# Altium schematic sheet Principal.V01.R00.SchDoc(Principal.V01.R00)
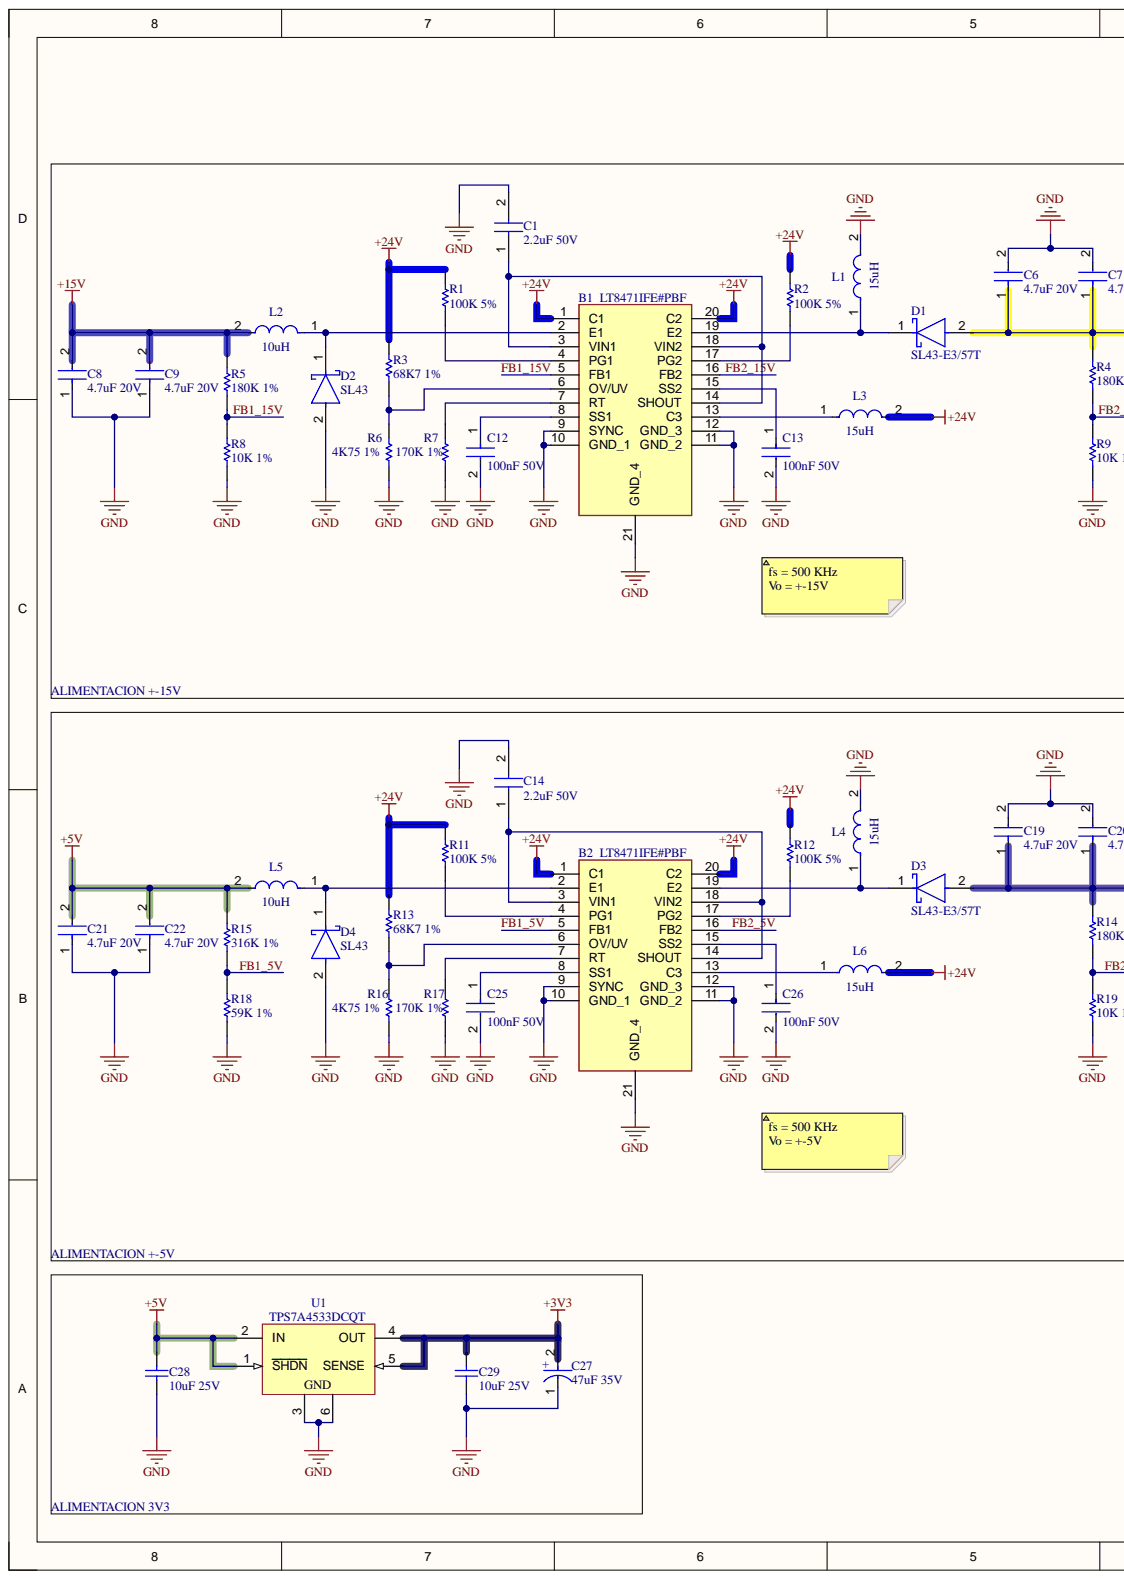
[diagram p0: Principal.V01.R00.SchDoc, left]
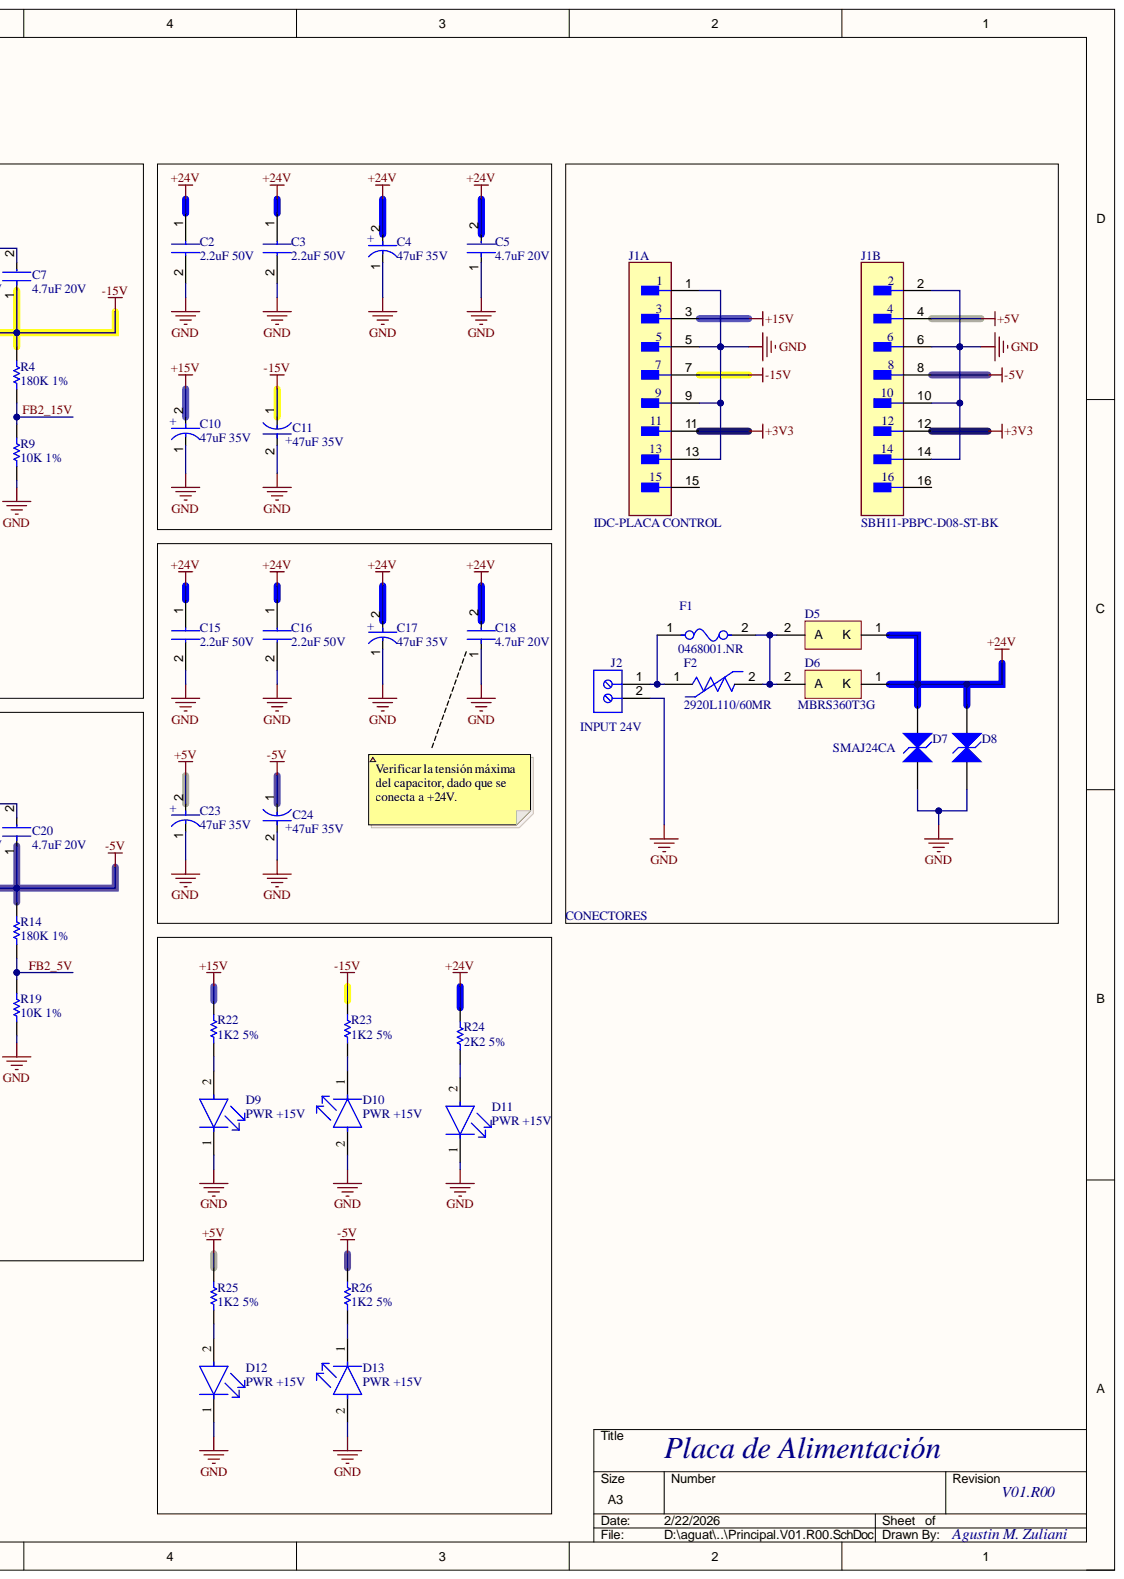
[diagram p1: Principal.V01.R00.SchDoc, right]

## Parts
B1  LT8471IFE#PBF  [SOP65P640X120-21N]
B2  LT8471IFE#PBF  [SOP65P640X120-21N]
C1  2.2uF 50V  [MBASx31x]
C2  2.2uF 50V  [MBASx31x]
C3  2.2uF 50V  [MBASx31x]
C4  47uF 35V  value 47uF  Capacitance: 47uF  [FP-FK-D-MFG]
C5  4.7uF 20V  [FP-CC1206-1_25-MFG]
C6  4.7uF 20V  [FP-CC1206-1_25-MFG]
C7  4.7uF 20V  [FP-CC1206-1_25-MFG]
C8  4.7uF 20V  [FP-CC1206-1_25-MFG]
C9  4.7uF 20V  [FP-CC1206-1_25-MFG]
C10  47uF 35V  value 47uF  Capacitance: 47uF  Voltage Rating: 35V  [FP-FK-D-MFG]
C11  47uF 35V  value 47uF  Capacitance: 47uF  Voltage Rating: 35V  [FP-FK-D-MFG]
C12  100nF 50V  [FP-C1206C-EB-MFG]
C13  100nF 50V  [FP-C1206C-EB-MFG]
C14  2.2uF 50V  [MBASx31x]
C15  2.2uF 50V  [MBASx31x]
C16  2.2uF 50V  [MBASx31x]
C17  47uF 35V  value 47uF  Capacitance: 47uF  Voltage Rating: 35V  [FP-FK-D-MFG]
C18  4.7uF 20V  [FP-CC1206-1_25-MFG]
C19  4.7uF 20V  [FP-CC1206-1_25-MFG]
C20  4.7uF 20V  [FP-CC1206-1_25-MFG]
C21  4.7uF 20V  [FP-CC1206-1_25-MFG]
C22  4.7uF 20V  [FP-CC1206-1_25-MFG]
C23  47uF 35V  value 47uF  Capacitance: 47uF  Voltage Rating: 35V  [FP-FK-D-MFG]
C24  47uF 35V  value 47uF  Capacitance: 47uF  Voltage Rating: 35V  [FP-FK-D-MFG]
C25  100nF 50V  [FP-C1206C-EB-MFG]
C26  100nF 50V  [FP-C1206C-EB-MFG]
C27  47uF 35V  value 47uF  Capacitance: 47uF  Voltage Rating: 35V  [FP-FK-D-MFG]
C28  10uF 25V  value 10uF  [CAPC1812(4532)280_L]
C29  10uF 25V  value 10uF  [CAPC1812(4532)280_L]
D1  SL43-E3/57T  [FP-95402-MFG]
D2  SL43  [FP-95402-MFG]
D3  SL43-E3/57T  [FP-95402-MFG]
D4  SL43  [FP-95402-MFG]
D5  MBRS360T3G  [ONSC-SMC-2-403-03_V]
D6  MBRS360T3G  [ONSC-SMC-2-403-03_V]
D7  SMAJ24CA  [VISH-DO-214AC-2_N]
D8  SMAJ24CA  [VISH-DO-214AC-2_N]
D9  PWR +15V  [FP-SML-LX0805UWC-TR-MFG]
D10  PWR +15V  [FP-SML-LX0805UWC-TR-MFG]
D11  PWR +15V  [FP-SML-LX0805UWC-TR-MFG]
D12  PWR +15V  [FP-SML-LX0805UWC-TR-MFG]
D13  PWR +15V  [FP-SML-LX0805UWC-TR-MFG]
F1  0468001.NR  [FP-0468-MFG]
F2  2920L110/60MR  [FP-2920L-MFG]
J1  IDC-PLACA CONTROL  Manufacturer Part Number: SBH11-PBPC-D08-ST-BK  [FP-SBH11-PBPC-D08-ST-BK-MFG]  (parts A,B)
J2  INPUT 24V  [691111710002]
L1  15uH  [FP-NR6045-MFG]
L2  10uH  [FP-NR10050-MFG]
L3  15uH  [FP-NR6045-MFG]
L4  15uH  [FP-NR6045-MFG]
L5  10uH  [FP-NR10050-MFG]
L6  15uH  [FP-NR6045-MFG]
R1  100K 5%  [RESC0805(2012)_M]
R2  100K 5%  [RESC0805(2012)_M]
R3  68K7 1%  [RESC0805(2012)_M]
R4  180K 1%  [RESC0805(2012)_M]
R5  180K 1%  [RESC0805(2012)_M]
R6  4K75 1%  [RESC0805(2012)_M]
R7  170K 1%  [RESC0805(2012)_M]
R8  10K 1%  [RESC0805(2012)_M]
R9  10K 1%  [RESC0805(2012)_M]
R11  100K 5%  [RESC0805(2012)_M]
R12  100K 5%  [RESC0805(2012)_M]
R13  68K7 1%  [RESC0805(2012)_M]
R14  180K 1%  [RESC0805(2012)_M]
R15  316K 1%  [RESC0805(2012)_M]
R16  4K75 1%  [RESC0805(2012)_M]
R17  170K 1%  [RESC0805(2012)_M]
R18  59K 1%  [RESC0805(2012)_M]
R19  10K 1%  [RESC0805(2012)_M]
R22  1K2 5%  [RESC0805(2012)_M]
R23  1K2 5%  [RESC0805(2012)_M]
R24  2K2 5%  [RESC0805(2012)_M]
R25  1K2 5%  [RESC0805(2012)_M]
R26  1K2 5%  [RESC0805(2012)_M]
U1  TPS7A4533DCQT  [DCQ0006A_M]

## Nets
+3V3: C27.2 C29.2 J1.11 J1.12 U1.4(OUT) U1.5(SENSE)
+5V: C21.2 C22.2 C23.2 C28.2 J1.4 L5.2 R15.2 R25.1 U1.1(SHDN) U1.2(IN)
+15V: C8.2 C9.2 C10.2 J1.3 L2.2 R5.2 R22.1
+24V: B1.1(C1) B1.20(C2) B2.1(C1) B2.20(C2) C2.1 C3.1 C4.2 C5.2 C15.1 C16.1 C17.2 C18.2 D5.1 D6.1 D7.2 D8.2 L3.2 L6.2 R1.2 R2.1 R3.2 R11.2 R12.1 R13.2 R24.1
-5V: C19.1 C20.1 C24.1 D3.2 J1.8 R14.2 R26.1
-15V: C6.1 C7.1 C11.1 D1.2 J1.7 R4.2 R23.1
GND: B1.9(SYNC) B1.10(GND_1) B1.11(GND_2) B1.12(GND_3) B1.21(GND_4) B2.9(SYNC) B2.10(GND_1) B2.11(GND_2) B2.12(GND_3) B2.21(GND_4) C1.2 C2.2 C3.2 C4.1 C5.1 C6.2 C7.2 C8.1 C9.1 C10.1 C11.2 C12.2 C13.2 C14.2 C15.2 C16.2 C17.1 C18.1 C19.2 C20.2 C21.1 C22.1 C23.1 C24.2 C25.2 C26.2 C27.1 C28.1 C29.1 D2.2 D4.2 D7.1 D8.1 D9.1 D10.2 D11.1 D12.1 D13.2 J1.1 J1.2 J1.5 J1.6 J1.9 J1.10 J1.13 J1.14 J2.2 L1.2 L4.2 R6.1 R7.1 R8.1 R9.1 R16.1 R17.1 R18.1 R19.1 U1.3 U1.6
FB1_5V: B2.5(FB1) R15.1 R18.2
FB1_15V: B1.5(FB1) R5.1 R8.2
FB2_5V: B2.16(FB2) R14.1 R19.2
FB2_15V: B1.16(FB2) R4.1 R9.2
NetB1_2: B1.2(E1) D2.1 L2.1
NetB1_3: B1.3(VIN1) B1.14(SHOUT) B1.18(VIN2) C1.1
NetB1_4: B1.4(PG1) R1.1
NetB1_6: B1.6(OV/UV) R3.1 R6.2
NetB1_7: B1.7(RT) R7.2
NetB1_8: B1.8(SS1) C12.1
NetB1_13: B1.13(C3) L3.1
NetB1_15: B1.15(SS2) C13.1
NetB1_17: B1.17(PG2) R2.2
NetB1_19: B1.19(E2) D1.1 L1.1
NetB2_2: B2.2(E1) D4.1 L5.1
NetB2_3: B2.3(VIN1) B2.14(SHOUT) B2.18(VIN2) C14.1
NetB2_4: B2.4(PG1) R11.1
NetB2_6: B2.6(OV/UV) R13.1 R16.2
NetB2_7: B2.7(RT) R17.2
NetB2_8: B2.8(SS1) C25.1
NetB2_13: B2.13(C3) L6.1
NetB2_15: B2.15(SS2) C26.1
NetB2_17: B2.17(PG2) R12.2
NetB2_19: B2.19(E2) D3.1 L4.1
NetD5_2: D5.2 D6.2 F1.2 F2.2
NetD9_2: D9.2 R22.2
NetD10_1: D10.1 R23.2
NetD11_2: D11.2 R24.2
NetD12_2: D12.2 R25.2
NetD13_1: D13.1 R26.2
NetF1_1: F1.1 F2.1 J2.1

Not connected: J1 15 16

## Library data of the parts
B1 B2 = LT8471IFE#PBF: DC/DC CONVERTER, MULTITOPOLOGY, 50V, TSSOP-20; Supply Voltage:50V; No. of Step-Down DC - DC Converters:2; No. of LDO Regulators:-; No. of Regulated Outputs:2; Digital IC Case:TSSOP; No. of Pins:20Pins; Operating Temperature Min:-40CRoHS Compliant: Yes; Manufacturer_Name: Analog Devices; Geometry.Height: 1.2mm; Component Kind: Standard; Datasheet Link: https://www.analog.com/media/en/technical-documentation/data-sheets/8471fd.pdf; Library Name: LT8471IFE#PBF.SchLib; Manufacturer_Part_Number: LT8471IFE#PBF; Mouser Part Number: 584-LT8471IFE#PBF; Mouser Price/Stock: https://www.mouser.co.uk/ProductDetail/Analog-Devices/LT8471IFEPBF?qs=hVkxg5c3xu%2FiDctk9AXMtQ%3D%3D
C1-C3 C14-C16 = MBASU31LSB7225KTNA01: Capacitor; Manufacturer_Name: TAIYO YUDEN; Component Kind: Standard; Datasheet Link: https://ds.yuden.co.jp/TYCOMPAS/or/detail?pn=MBASU31LSB7225KTNA01; Height: 1.6mm; Library Name: SamacSys.SchLib; Manufacturer_Part_Number: MBASU31LSB7225KTNA01
C4 C10 C11 C17 C23 C24 C27 = CMP-05427-000002-1: CAP ALUM 47UF 20% 35V SMD; Manufacturer: Panasonic; Manufacturer Part Number: EEE-FK1V470P; Automotive Grade: Grade 2; Dissipation Factor: 12%; Esr: 0.36Ω; Leakage Current: 16.45uA; Life: 2000Hours; Material: Aluminum; Ripple Current: 240mA; Automotive: Yes; Category: Cap; Component Kind: Standard; ComponentLink2Description: Datasheet; ComponentLink2URL: https://industrial.panasonic.com/cdbs/www-data/pdf/RDE0000/ABA0000C1181.pdf; Device Class L1: Passive Components; Device Class L2: Capacitors; Device Class L3: Aluminum Electrolytic Capacitors; Digikey Description: CAP ALUM 47UF 20% 35V SMD; Height: 6.1mm; Lead Free: Yes; Library Name: Altium Content Vault; Mouser Description: Capacitor Aluminum Electrolytic 47uF 35 Volt 20% (6.3 X 5.8mm) Surface Mount 240mA 2000h 105C Automotive; Octopart: https://octopart.com/eeefk1v470p-panasonic-4642848; Package: FK-D; RoHS: TRUE; Temperature: -55°C to +105°C; Temperature Range High: +105°C; Temperature Range Low: -55°C; Tolerance: 20%
  C4: Voltage Rating: 35V
C5-C9 C18-C22 = CMP-03422-001171-1: CAP CER 1UF 10V X7R 1206; Manufacturer: Yageo; Manufacturer Part Number: CC1206KKX7R6BB105; Automotive Grade: NA; Material: Ceramic; Temperature Coefficient: 15%; Tolerance: 0.1; Automotive: No; Capacitance: 1 uF; Category: Cap; Component Kind: Standard; ComponentLink2Description: Datasheet; ComponentLink2URL: http://www.yageo.com/documents/recent/UPY-NP0X7R_HV_1KV-to-3KV_11.pdf; Device Class L1: Passive Components; Device Class L2: Capacitors; Device Class L3: Ceramic Capacitors; Digikey Description: CAP CER 1UF 10V X7R 1206; Footprint Url: http://www.yageo.com/exep/pages/download/literatures/UPY-C_GEN_24.pdf; Height: 1.45mm; Lead Free: Yes; Library Name: Altium Content Vault; Mouser Description: Multilayer Ceramic Capacitors MLCC - SMD/SMT 1.0uF 10V X7R 10%; Octopart: https://octopart.com/cc1206kkx7r6bb105-yageo-21769912; Package: CC1206-1.25; RoHS: TRUE; Temperature: -55°C to +125°C; Temperature Characteristic: X7R; Temperature Range High: +125°C; Temperature Range Low: -55°C; Value: 1 uF; Voltage Rating: 10 V
C12 C13 C25 C26 = CMP-03020-005759-1: CAP CER 0.1UF 50V X7R 1206; Manufacturer: KEMET; Manufacturer Part Number: C1206C104K5RACAUTO; Automotive Grade: Grade 0; Capacitance: 0.10 μF; Material: Ceramic; Temperature Coefficient: 15%; Tolerance: 0.1; Value: 0.10 μF; Automotive: Yes; Category: Cap; Component Kind: Standard; ComponentLink2Description: Datasheet; ComponentLink2URL: https://content.kemet.com/datasheets/KEM_C1007_X8R_ULTRA_150C_SMD.pdf; Device Class L1: Passive Components; Device Class L2: Capacitors; Device Class L3: Ceramic Capacitors; Digikey Description: CAP CER 0.1UF 50V X7R 1206; Height: 0.88mm; Ipc Land Pattern Name: CAPC320160X78; Lead Free: Yes; Library Name: Altium Content Vault; Mouser Description: Multilayer Ceramic Capacitors MLCC - SMD/SMT 0.1uF 50volts 10% X7R AUTO; Octopart: https://octopart.com/c1206c104k5racauto-kemet-12595586; Package: C1206C-EB; RoHS: TRUE; Temperature: -55°C to +150°C; Temperature Characteristic: X7R; Temperature Range High: +150°C; Temperature Range Low: -55°C; Voltage Rating: 50 V
C28 C29 = CMP-1040-01657-2: CAP 10uF 25V ±20% 1812 (4532 Metric) Thickness 2.8mm SMD; Case-EIA: 1812; Case-Metric: 4532; Tolerance: Â±20%; Component Kind: Standard; Library Name: Altium Content Vault; Max Thickness: 2.8 mm; Rated Voltage: 25 V; Technology: SMT
D1-D4 = CMP-02539-000070-1: DIODE SCHOTTKY 30V 4A DO214AB; Element Configuration: Single; Forward Current: 8A; Forward Voltage: 470mV; Max Forward Surge Current (Ifsm): 150A; Max Reverse Current: 500uA; Polarity: Standard; Average Rectified Current: 4A; Case/Package: DO-214AB; Component Kind: Standard; Current Rating: 4A; Height: 0.095inch; Lead Free: Lead Free; Length: 0.279inch; Library Name: Altium Content Vault; Max Operating Temperature: 125°C; Max Repetitive Reverse Voltage (Vrrm): 30V; Max Reverse Voltage (DC): 30V; Min Operating Temperature: -55°C; Mount: Surface Mount; Number of Pins: 2; Output Current: 4A; Package Quantity: 1; Packaging: Tape and Reel; Peak Non-Repetitive Surge Current: 150A; Voltage Rating (DC): 30V; Width: 0.245inch
D5 D6 = CMP-1055-00394-1: Schottky Power Rectifier, 2-Pin SMC, Pb-Free, Tape and Reel; Manufacturer: On Semiconductor; Configuration: Single; IFSM Max (A): 125; Component Kind: Standard; ComponentLink1Description: Manufacturer URL; ComponentLink1URL: http://www.onsemi.com/; ComponentLink2Description: Datasheet; ComponentLink2URL: http://www.onsemi.cn/pub_link/Collateral/MBRS360T3-D.PDF; ComponentLink3Description: Package Specification; ComponentLink3URL: http://www.onsemi.com/pub_link/Collateral/403-03.PDF; DatasheetVersion: Rev. 8; Library Name: Altium Content Vault; Mounting Technology: Surface Mount; PackageDescription: 2-Pin Small Molded Package, J-Bend Lead, Body 5.845 x 6.855 mm; PackageReference: SMC-2-403-03; PackageVersion: Rev. E, 08/2005; Packing: Tape and Reel; PartNumber: MBRS360T3G; RoHS: TRUE
D7 D8 = CMP-0548-00269-2: Transient Voltage Suppressor, 6.40 to 231 V, 24 V Stand-off Voltage, Bi-Directional, -55 to 155 degC, 2-pin DO-214AC, Pb-Free, Tape and Reel; Manufacturer: Vishay Semiconductor; PartNumber: SMAJ24CA-E3/5A; ID (uA): 2; IFSM: 40 A; PPPM (W): 400, 300; VBR Bi-Directional (V): 6.4 to 231; VWM (V): 24; Component Kind: Standard; ComponentLink1Description: Manufacturer URL; ComponentLink1URL: http://www.vishay.com/; ComponentLink2Description: Datasheet; ComponentLink2URL: http://www.vishay.com/docs/88390/smaj50a.pdf; ComponentLink3Description: Package Specification; ComponentLink3URL: http://www.vishay.com/docs/88390/smaj50a.pdf; DatasheetVersion: 12/8/2013; Library Name: Altium Content Vault; Mounting Technology: Surface Mount; Operating Junction and Storage Temperature Range: -55 to 150 degC; PackageDescription: 2-Pin DO-214AC (SMA), Body 4.245 x 2.665 mm; PackageReference: DO-214AC-2; Packing: Tape and Reel; RoHS: TRUE
D9-D13 = CMP-00229-00139794-1: SML-LX0805UWC-TR White LED, 0805 Diffused, Rectangle Lens SMD package; NexarPartId: 139794; Approvals: CSA, UL; Forward Current: 20mA; Forward Voltage: 3.5V; Lens Color: Clear, Yellow; Luminous Intensity: 150mcd; Number of LEDs: 1; Power Rating: 120mW; Viewing Angle: 120°; Case/Package: 0805; Component Kind: Standard; Illumination Color: White; Library Name: Altium Content Vault; Max Operating Temperature: 85°C; Min Operating Temperature: -40°C; Mount: Surface Mount; RoHS: Compliant
F1 = CMP-2000-05753-2: FUSE BOARD MNT 1A 63VAC/VDC 1206; Manufacturer: Littelfuse; Fuse Blow Speed: Slow; Fuse I2T: 0.127A2Sec; Fuse Interrupt Ac Current: 50A; Fuse Voltage Drop: 94.7mV; Max Fuse Ac Voltage: 63V; Resistance: 0.079Ω; Value: 0.079Ω; Automotive: No; Category: Fuse; Component Kind: Standard; ComponentLink2Description: Datasheet; ComponentLink2URL: https://m.littelfuse.com/~/media/electronics/datasheets/fuses/littelfuse_fuse_468_datasheet.pdf.pdf; Device Class L1: Circuit Protection; Device Class L2: Fuses; Device Class L3: unset; Digikey Description: FUSE BOARD MNT 1A 63VAC/VDC 1206; Fuse Current: 1A; Fuse Interrupt Dc Current: 50A; Height: 0.762mm; Lead Free: Yes; Library Name: Altium Content Vault; Manufacturer Part Number: 0468001.NR; Max Fuse Dc Voltage: 63V; Mouser Description: Surface Mount Fuses 1A Slo-Blo; Octopart: https://octopart.com/0468001.nr-littelfuse-566477; Package: 0468; RoHS: TRUE; Temperature: -55°C to +90°C; Temperature Range High: +90°C; Temperature Range Low: -55°C; Voltage Rating: 63V
F2 = CMP-08606-000028-1: PTC RESET FUSE 60V 1.1A 2920; Manufacturer: Littelfuse; Current Rating: 20A; Resistance: 0.12-0.41Ω; Trip Current: 2.2A; Trip Time: 0.5s; Value: 0.12-0.41Ω; Ambient Temperature Range High: +85°C; Ambient Temperature Range Low: -40°C; Automotive: No; Category: Fuse; Component Kind: Standard; ComponentLink2Description: Datasheet; ComponentLink2URL: https://m.littelfuse.com/~/media/electronics/datasheets/resettable_ptcs/littelfuse_ptc_2920l_datasheet.pdf.pdf; Device Class L1: Circuit Protection; Device Class L2: PTC Resettable Fuses; Device Class L3: unset; Digikey Description: PTC RESET FUSE 60V 1.1A 2920; Height: 2mm; Hold Current: 1.1A; Lead Free: Yes; Library Name: Altium Content Vault; Manufacturer Part Number: 2920L110/60MR; Octopart: https://octopart.com/2920l110%2F60mr-littelfuse-75250824; Package: 2920L; RoHS: TRUE; Temperature: -40°C to +85°C; Temperature Range High: +85°C; Temperature Range Low: -40°C; Voltage Rating: 60V
J1 = CMP-25680-000019-1: CONN HEADER VERT 16POS 2.54MM; Manufacturer: Sullins; Conn Gender: Male; Conn Orientation: Straight; Current Rating: 3A; Number Of Contacts: 16; Standoff Height: 0.3mm; Automotive: No; Category: Conn; Component Kind: Standard; ComponentLink2Description: Datasheet; ComponentLink2URL: https://drawings-pdf.s3.amazonaws.com/11091.pdf; Contact Material: Gold,Nickel; Device Class L1: Connectors; Device Class L2: Headers and Wire Housings; Device Class L3: unset; Digikey Description: CONN HEADER VERT 16POS 2.54MM; Height: 9.3mm; Lead Free: Yes; Library Name: Altium Content Vault; Number Of Rows: 2; Octopart: https://octopart.com/sbh11-pbpc-d08-st-bk-sullins-12270491; Package: 0; Pitch: 2.54mm; RoHS: TRUE; Temperature: -40°C to +105°C; Temperature Range High: +105°C; Temperature Range Low: -40°C
J2 = CMP-1502-03262-3: 111 Series 5MM Pitch Straight, Pcb Terminal Block, Through Hole, 2 Way; Current Rating: 8A; Number of Contacts: 2; Number of Levels: 1; Pitch: 5.0038mm; Stripping Length: -6mm; Voltage Rating: 300V; Wire/Cable Gauge: 26AWG; Case/Package: J; Color: Green; Component Kind: Standard; Contact Material: Brass; Contact Plating: Tin; Height: 14mm; Housing Material: Nylon; Lead Pitch: 5mm; Length: 7.6mm; Library Name: Altium Content Vault; Max Operating Temperature: 105°C; Min Operating Temperature: -40°C; Number of Pins: 2; Number of Positions Per Level: 2; Packaging: Box; REACH SVHC: Unknown; RoHS: Compliant; Termination: Screw; Width: 11.2mm
L1 L3 L4 L6 = CMP-14484-001063-1: Shielded Wirewound Inductor 15uH 20% 2.3A 91mΩ SMD; Core Material: Ferrite; Current Rating: 1.9A; DC Resistance (DCR): 91mR; Saturation Current: 2.5A; Self Resonant Frequency: 10MHz; Test Frequency: 100kHz; Case/Package: 6045; Component Kind: Standard; Depth: 6.2mm; Halogen Free: Halogen Free; Height: 4.5mm; Height - Seated (Max): 0.177inch; Inductance: 15uH; Inductance Tolerance: 20%; Lead Free: Lead Free; Lead Pitch: 4.7mm; Length: 6mm; Library Name: Altium Content Vault; Max DC Current: 1.9A; Max Operating Temperature: 125°C; Min Operating Temperature: -25°C; Mount: Surface Mount; Number of Pins: 2; Package Quantity: 1500; Packaging: Tape and Reel; Radiation Hardening: No; Resistor Type: Wirewound; RMS Current (Irms): 1.9A; RoHS Compliant: Yes; Series: NRS; Shielding: Shielded; Termination: SMD/SMT; Tolerance: 20%; Width: 6mm
L2 L5 = CMP-14484-000424-1: Inductor Power Shielded Wirewound 10uH 20% 100KHz Ferrite 4.1A 32.5mΩ DCR T/R; DC Resistance (DCR): 32.5mR; Max DC Current: 4.7A; Self Resonant Frequency: 15MHz; Case/Package: Nonstandard; Component Kind: Standard; Core Material: Ferrite; Current Rating: 4.1A; Halogen Free: Halogen Free; Height: 5mm; Height - Seated (Max): 0.197inch; Inductance: 10uH; Inductance Tolerance: 20%; Lead Free: Lead Free; Length: 0.394inch; Library Name: Altium Content Vault; Max Operating Temperature: 105°C; Min Operating Temperature: -25°C; Mount: Surface Mount; Number of Pins: 2; Package Quantity: 500; Packaging: Tape and Reel; Resistor Type: Wirewound; RMS Current (Irms): 4.1A; RoHS Compliant: Yes; Saturation Current: 4.7A; Series: NR; Shielding: Shielded; Termination: SMD/SMT; Test Frequency: 100kHz; Tolerance: 20%; Width: 0.386inch
R1-R9 R11-R19 R22-R26 = CMP-1013-00746-1: 124K 0.125W 1% 0805 (2012 Metric)  SMD; Case-EIA: 0805; Case-Metric: 2012; Value: 124000.00 Ohm; Component Kind: Standard; Library Name: Altium Content Vault; Power: 0.125 W; Technology: SMT; Tolerance: 1 %
U1 = CMP-0395-00359-2: Single Output Fast Transient Response LDO, 1.5 A, Fixed 3.3 V Output, 2.1 to 20 V Input, 6-pin SOT-223 (DCQ), -40 to 125 degC, Green (RoHS & no Sb/Br); Manufacturer: Texas Instruments; Accuracy(%): 1; Fixed Output Options(V): 3.3; Iout(Max)(A): 1.5; SubFamily: Single Channel LDO; Vdo(Typ)(mV): 300; Vin(Max)(V): 20; Vin(Min)(V): 2.1; Component Kind: Standard; ComponentLink1Description: Manufacturer URL; ComponentLink1URL: http://www.ti.com/; ComponentLink2Description: Datasheet; ComponentLink2URL: http://www.ti.com/general/docs/lit/getliterature.tsp?genericPartNumber=TPS7A4533&fileType=pdf; ComponentLink3Description: Package Specification; ComponentLink3URL: http://www.ti.com/litv/pdf/mpds098c; DatasheetVersion: SLVS720D; Eco-Plan: Green (RoHS & no Sb/Br); Iq(Typ)(mA): 1; Library Name: Altium Content Vault; Mounting Technology: Surface Mount; PackageDescription: 6-Pin Plastic Small Outline, Body 3.5 x 6.5 mm, Pitch 1.27 mm; PackageReference: DCQ0006A; PackageVersion: 4202109/D; PartNumber: TPS7A4533DCQT; Vout Adj(Max)(V): 3.3; Vout Adj(Min)(V): 3.3
